# Altium schematic sheet 6-Gyro_Scope..SchDoc(6-Gyro_Scope.)
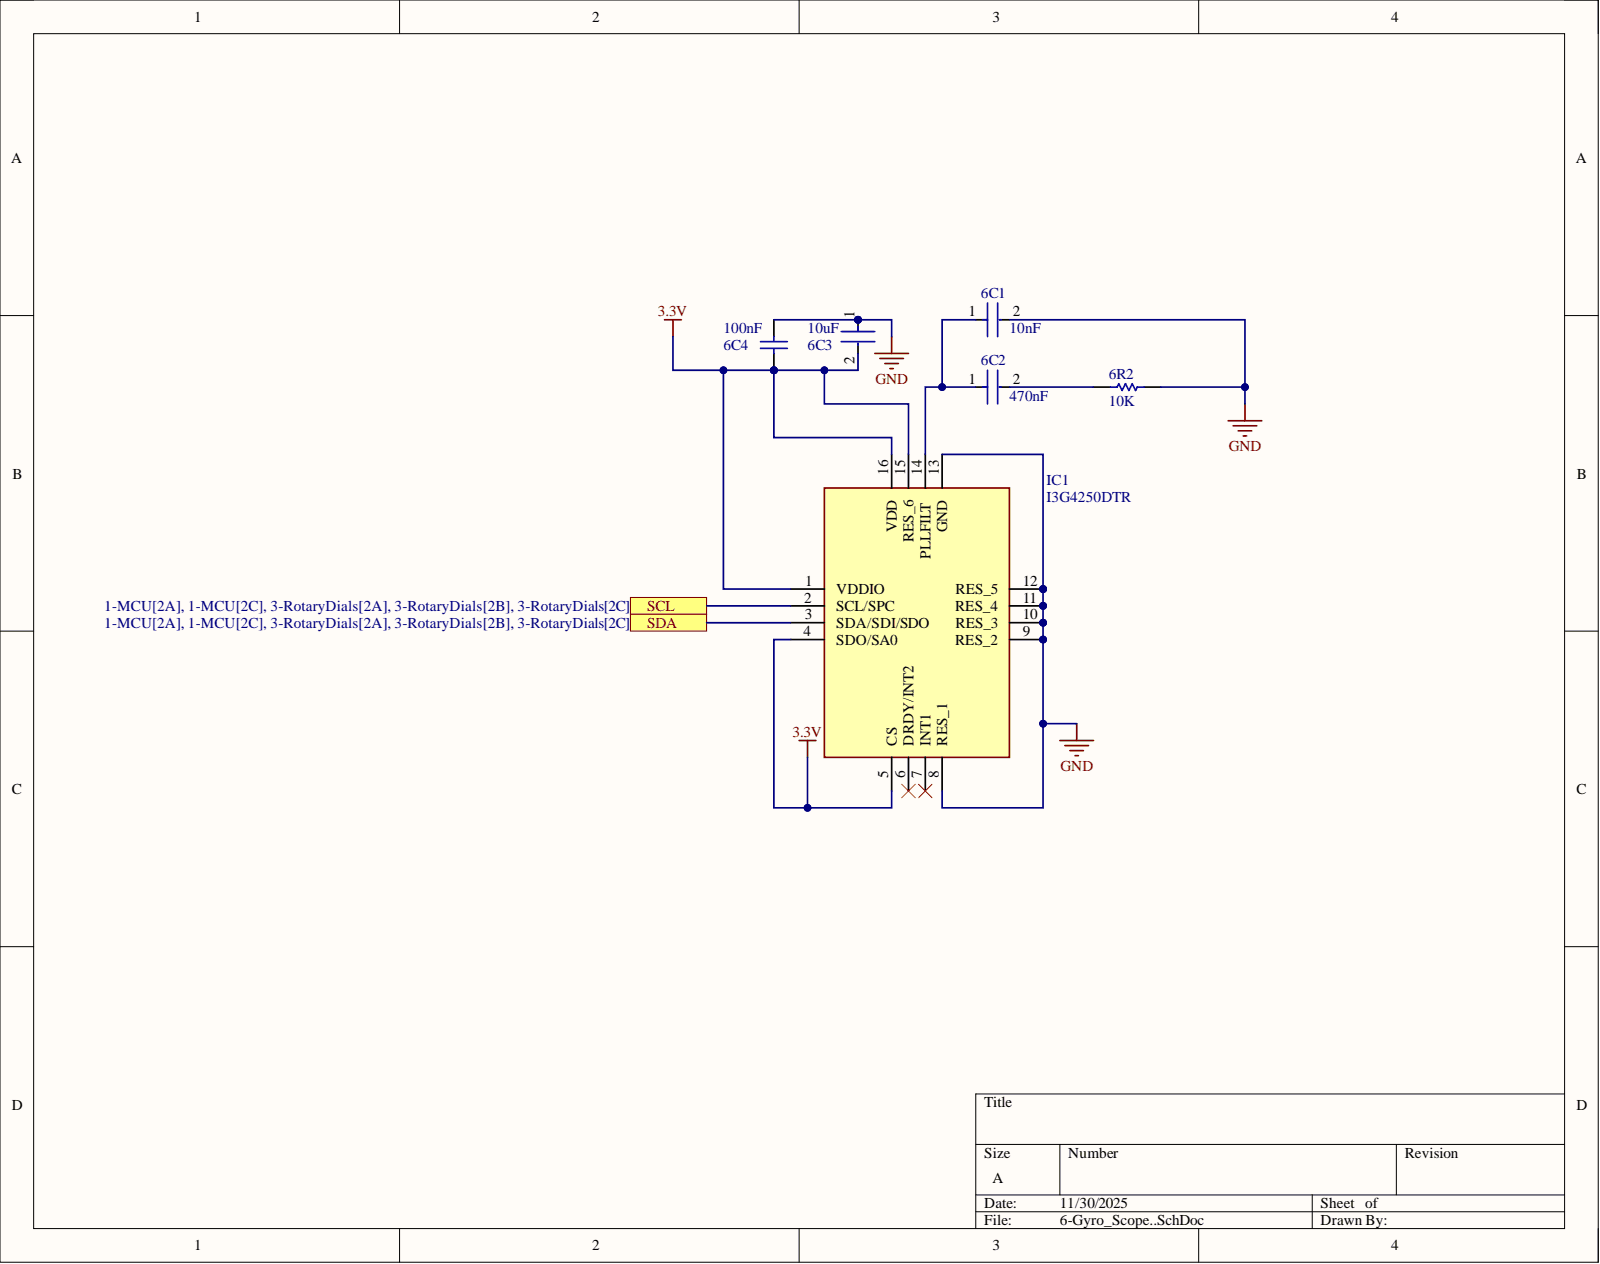
[diagram p0: 6-Gyro_Scope..SchDoc]

## Parts
6C1  10nF
6C2  470nF
6C3  10uF
6C4  100nF
6R2  10K
IC1  I3G4250DTR

## Ports
SCL, SDA

## Nets
3.3V: 6C3.2 6C4.1 IC1.1(VDDIO) IC1.4(SDO/SA0) IC1.5(CS) IC1.15(RES_6) IC1.16(VDD)
GND: 6C1.2 6C3.1 6C4.2 6R2.2 IC1.8(RES_1) IC1.9(RES_2) IC1.10(RES_3) IC1.11(RES_4) IC1.12(RES_5) IC1.13(GND)
SCL: IC1.2(SCL/SPC) ; port SCL
SDA: IC1.3(SDA/SDI/SDO) ; port SDA
Net6C1_1: 6C1.1 6C2.1 IC1.14(PLLFILT)
Net6C2_2: 6C2.2 6R2.1

Not connected: IC1 6(DRDY/INT2) 7(INT1)

## Library data of the parts
6C1 = CMP-2006-03046-3: CAP CER 10000PF 50V X7R 0603 10nF   C0603C103K5RACTU; Manufacturer: KEMET; Automotive Grade: Grade 1; Capacitance: 10,000 pF; Temperature Coefficient: 30ppm/°C; Tolerance: 0.1; Value: 10,000 pF; Automotive: Yes; Category: Cap; Component Kind: Standard; ComponentLink2Description: Datasheet; ComponentLink2URL: https://api.kemet.com/component-edge/download/datasheet/C0603C100J5GACAUTO.pdf; Device Class L1: Passive Components; Device Class L2: Capacitors; Device Class L3: Ceramic Capacitors; Digikey Description: CAP CER 10000PF 50V X7R 0603; Height: 0.87mm; Lead Free: Yes; Library Name: Altium Content Vault; Manufacturer Part Number: C0603C103K5RACTU; Material: Ceramic; Mouser Description: Ceramic Capacitor 0.01Uf, 50V, X7R, 10%, 0603, Full Reel; Octopart: https://octopart.com/c0603c103k5ractu-kemet-133094; Package: C0603C-CF; RoHS: TRUE; Temperature: -55°C to +125°C; Temperature Characteristic: X7R; Temperature Range High: +125°C; Temperature Range Low: -55°C; Voltage Rating: 50 V
6C2 = CMP-06035-010465-1: Chip Multilayer Ceramic Capacitors for General Purpose, 0201, 0.47uF, X5R, 15%, 10%, 6.3V 470nF   GRM033R60J474KE90D; Capacitance: 470nF; Case Code (Imperial): 0201; Case Code (Metric): 0603; Case/Package: 0201; Component Kind: Standard; Depth: 0.3mm; Dielectric: X5R; Height: 0.3mm; Lead Free: Lead Free; Length: 0.6mm; Library Name: Altium Content Vault; Max Operating Temperature: 85°C; Min Operating Temperature: -55°C; Mount: Surface Mount; Package Quantity: 15000; Packaging: Tape and Reel; Termination: SMD/SMT; Thickness: 0.013inch; Tolerance: 10%; Voltage Rating: 6.3V; Voltage Rating (DC): 6.3V; Weight: 1.2E-05oz; Width: 0.012inch
6C3 = CMP-06035-062693-1: CAP CER 10UF 10V X5R 0603 10uF GRM188R61A106KE69J; Tolerance: 10%; Capacitance: 10uF; Component Kind: Standard; Dielectric: X5R; Length: 1.6mm; Library Name: Altium Content Vault; RoHS Compliant: Yes; Thickness: 800um; Voltage Rating (DC): 10V; Width: 800um
6C4 = CMP-1038-01631-1: CAP 100nF 10V ±20% 1210 (3225 Metric) Thickness 1mm SMD CAP 100nF 10V 1210(3225); Case-EIA: 1210; Case-Metric: 3225; Tolerance: Â±20%; Component Kind: Standard; Library Name: Altium Content Vault; Max Thickness: 1 mm; Rated Voltage: 10 V; Technology: SMT; Value: 100nF
6R2 = CMP-2003-04958-1: RC1206JR-101KL; Manufacturer: Yageo; Component Kind: Standard; Library Name: Altium Content Vault; Part Number: RC1206JR-101KL
IC1 = I3G4250DTR: Integrated Circuit; Manufacturer_Name: STMicroelectronics; Arrow Part Number: I3G4250DTR; Arrow Price/Stock: https://www.arrow.com/en/products/i3g4250dtr/stmicroelectronics?utm_currency=USD&region=europe; Component Kind: Standard; Datasheet Link: https://www.st.com/resource/en/datasheet/i3g4250d.pdf; Manufacturer_Part_Number: I3G4250DTR; Mouser Part Number: 511-I3G4250DTR; Mouser Price/Stock: https://www.mouser.co.uk/ProductDetail/STMicroelectronics/I3G4250DTR?qs=v3MacVxtdDJPIS9io4RmPA%3D%3D
